# Altium schematic sheet ch347-extboard.SchDoc
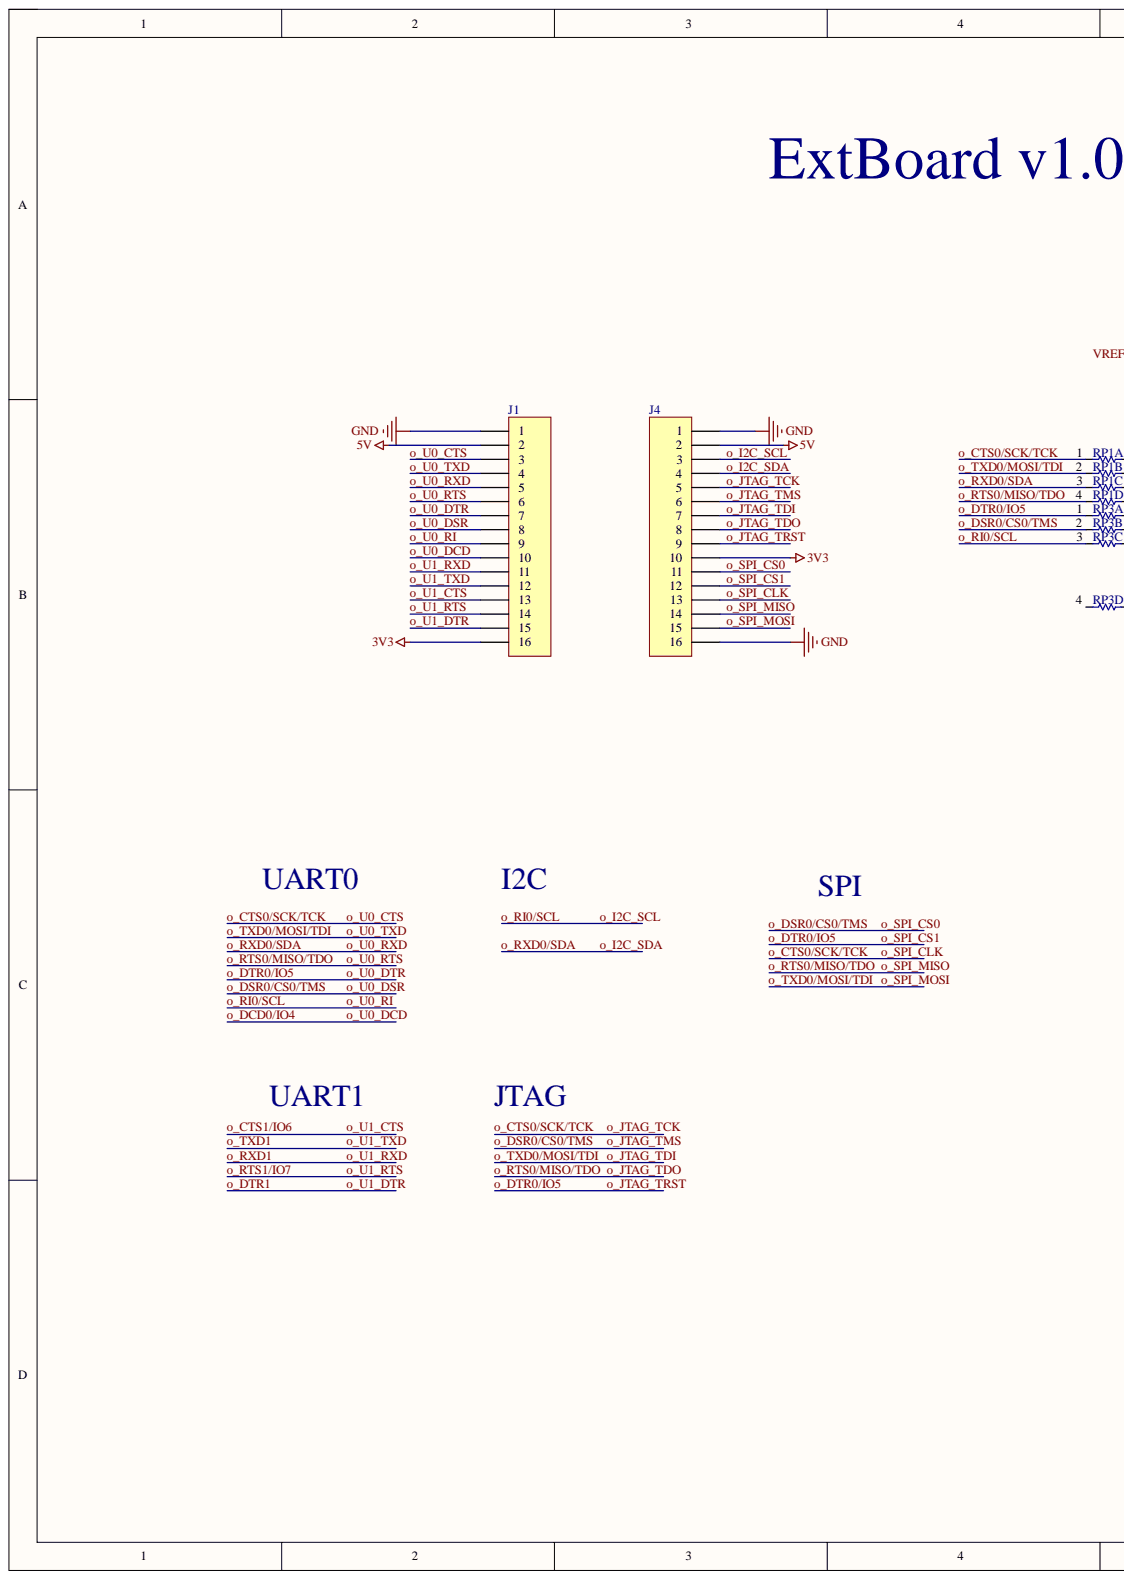
[diagram p0: ch347-extboard.SchDoc, left]
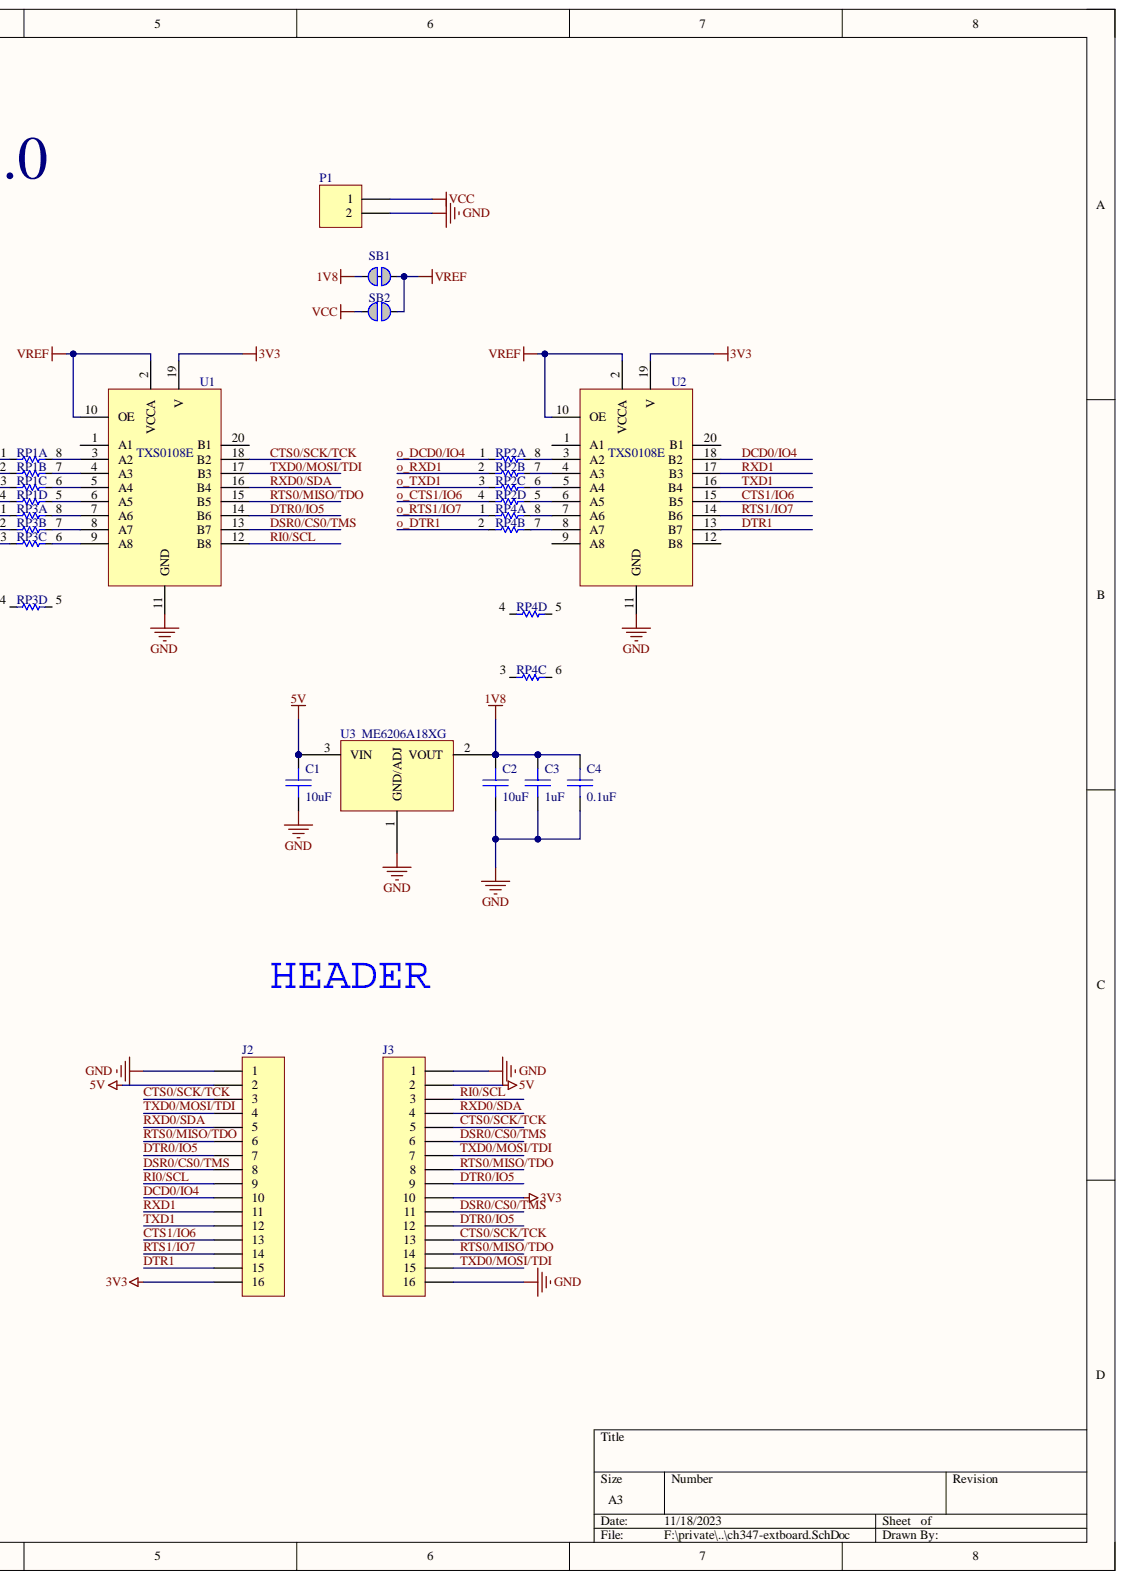
[diagram p1: ch347-extboard.SchDoc, right]

## Parts
C1  10uF  [C 0402_M_new]
C2  10uF  [C 0402_M_new]
C3  1uF  [C 0402_M_new]
C4  0.1uF  [C 0402_M_new]
J1  Header 16  [HEADER_16x1]  (value not drawn)
J2  Header 16  [HEADER_16x1]  (value not drawn)
J3  Header 16  [HEADER_16x1]  (value not drawn)
J4  Header 16  [HEADER_16x1]  (value not drawn)
P1  Header 2  [HEADER_2x1]  (value not drawn)
RP1  22R  [RCA-8P4R-0402]  (value not drawn)  (parts A,B,C,D)
RP2  22R  [RCA-8P4R-0402]  (value not drawn)  (parts A,B,C,D)
RP3  22R  [RCA-8P4R-0402]  (value not drawn)  (parts A,B,C,D)
RP4  22R  [RCA-8P4R-0402]  (value not drawn)  (parts A,B,C,D)
SB1  Solderbridge  [Solderbridge_Shorted]  (value not drawn)
SB2  Solderbridge  [Solderbridge]  (value not drawn)  (no BOM)
U1  TXS0108E  [VQFN-20_L4.6-W3.6-P0.50-BL-EP]
U2  TXS0108E  [VQFN-20_L4.6-W3.6-P0.50-BL-EP]
U3  ME6206A18XG  [SOT23-3M]

## Nets
1V8: C2.1 C3.1 C4.1 SB1.2 U3.2
3V3: J1.16 J2.16 J3.10 J4.10 U1.19(V) U2.19(V)
5V: C1.1 J1.2 J2.2 J3.2 J4.2 U3.3
GND: C1.2 C2.2 C3.2 C4.2 J1.1 J2.1 J3.1 J3.16 J4.1 J4.16 P1.2 U1.11(GND) U2.11(GND) U3.1
VCC: P1.1 SB2.1
VREF: SB1.1 SB2.2 U1.2(VCCA) U1.10(OE) U2.2(VCCA) U2.10(OE)
CTS0/SCK/TCK: J2.3 J3.5 J3.13 U1.18(B2)
CTS1/IO6: J2.13 U2.15(B5)
DCD0/IO4: J2.10 U2.18(B2)
DSR0/CS0/TMS: J2.8 J3.6 J3.11 U1.13(B7)
DTR0/IO5: J2.7 J3.9 J3.12 U1.14(B6)
DTR1: J2.15 U2.13(B7)
o_CTS0/SCK/TCK: J1.3 J4.5 J4.13 RP1.1
o_CTS1/IO6: J1.13 RP2.4
o_DCD0/IO4: J1.10 RP2.1
o_DSR0/CS0/TMS: J1.8 J4.6 J4.11 RP3.2
o_DTR0/IO5: J1.7 J4.9 J4.12 RP3.1
o_DTR1: J1.15 RP4.2
o_I2C_SCL: J1.9 J4.3 RP3.3
o_I2C_SDA: J1.5 J4.4 RP1.3
o_JTAG_TDI: J1.4 J4.7 J4.15 RP1.2
o_JTAG_TDO: J1.6 J4.8 J4.14 RP1.4
o_RTS1/IO7: J1.14 RP4.1
o_RXD1: J1.11 RP2.2
o_TXD1: J1.12 RP2.3
RI0/SCL: J2.9 J3.3 U1.12(B8)
RTS0/MISO/TDO: J2.6 J3.8 J3.14 U1.15(B5)
RTS1/IO7: J2.14 U2.14(B6)
RXD0/SDA: J2.5 J3.4 U1.16(B4)
RXD1: J2.11 U2.17(B3)
TXD0/MOSI/TDI: J2.4 J3.7 J3.15 U1.17(B3)
TXD1: J2.12 U2.16(B4)
NetRP1_5: RP1.5 U1.6(A5)
NetRP1_6: RP1.6 U1.5(A4)
NetRP1_7: RP1.7 U1.4(A3)
NetRP1_8: RP1.8 U1.3(A2)
NetRP2_5: RP2.5 U2.6(A5)
NetRP2_6: RP2.6 U2.5(A4)
NetRP2_7: RP2.7 U2.4(A3)
NetRP2_8: RP2.8 U2.3(A2)
NetRP3_6: RP3.6 U1.9(A8)
NetRP3_7: RP3.7 U1.8(A7)
NetRP3_8: RP3.8 U1.7(A6)
NetRP4_7: RP4.7 U2.8(A7)
NetRP4_8: RP4.8 U2.7(A6)

Not connected: RP3 4 5; RP4 3 4 5 6; U1 1(A1) 20(B1); U2 1(A1) 9(A8) 12(B8) 20(B1)

## Library data of the parts
C1-C4 = SMT_C_0402: Surface mount capacitor 0402; Component Kind: Standard; Library Name: Vowstar_Basic_Device.IntLib
P1 = Header 2: Header, 4-Pin; Component Kind: Standard; LatestRevisionDate: 17-Jul-2002; LatestRevisionNote: Re-released for DXP Platform.; Signal Integrity: Connector; Library Name: nanoDAP.SCHLIB; Publisher: Altium Limited
RP1-RP4 = R_pack: Component Kind: Standard; Library Name: ICESugar.SCHLIB
SB1 = Solderbridge Shorted: Shorted Solderbridge; Artikkelnr Noca: N.A.; Database Table Name: Connectors$; Artikkelnr Simpro: N.A.; Component Kind: Net Tie; Footprint Doc: Solderbridge_Shorted; LatestRevisionDate: 2012-08-07; Library Name: Nordic Passive DB.DbLib; Part Number: Solderbridge Shorted; Pin Count: 2; Published: 2012-08-07; Value: Solderbridge; Publisher: Nordic Semiconductor
SB2 = SOLDERBRIDGE: Artikkelnr Noca: N.A.; Artikkelnr Simpro: N.A.; Description: Solderbridge; Footprint Doc: Solderbridge; LatestRevisionDate: 2009-12-14; Library Name: NODEMCU_ESP12.SCHLIB; Part Number: Solderbridge; Pin Count: 2; Published: 2009-12-14; Value: Solderbridge; Publisher: Nordic Semiconductor
U3 = NCP1117: NCP1117, NCV1117. 1.0 A Low-Dropout Positive  Fixed and Adjustable  Voltage Regulators; Component Kind: Standard; Library Name: Vowstar_IC_General.IntLib
J1-J4 = Header 16: Component Kind: Standard; Library Name: ch347.SCHLIB
U1 U2 = TXS0108E: Component Kind: Standard; Library Name: nanoDAP.SCHLIB
